# Altium schematic sheet SEPL-306Rev1.03.SchDoc
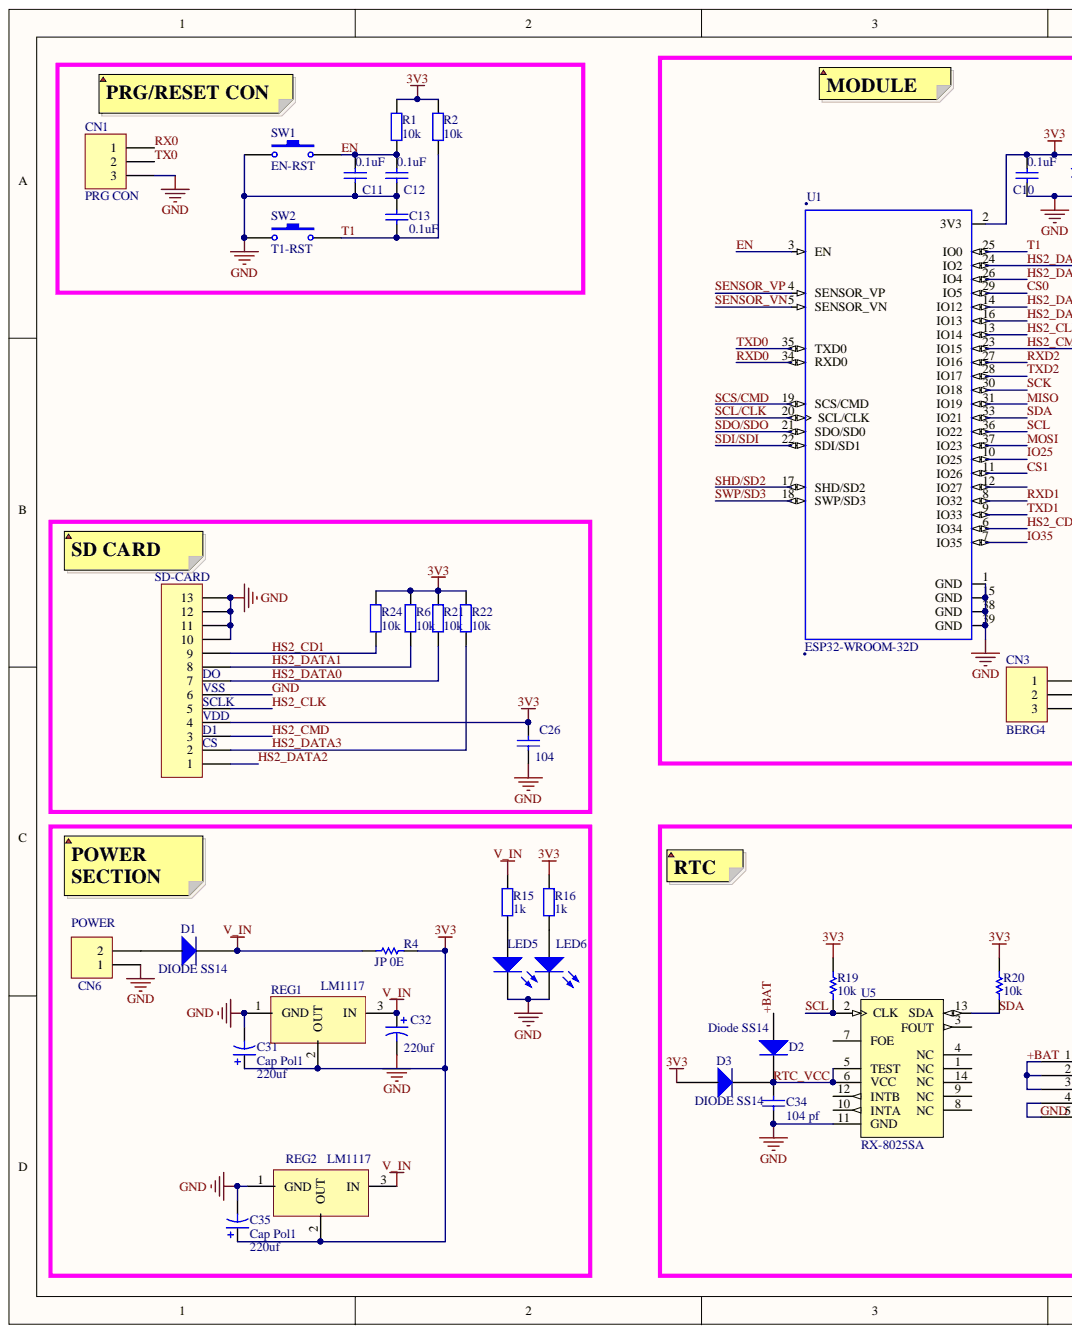
[diagram p0: SEPL-306Rev1.03.SchDoc, left]
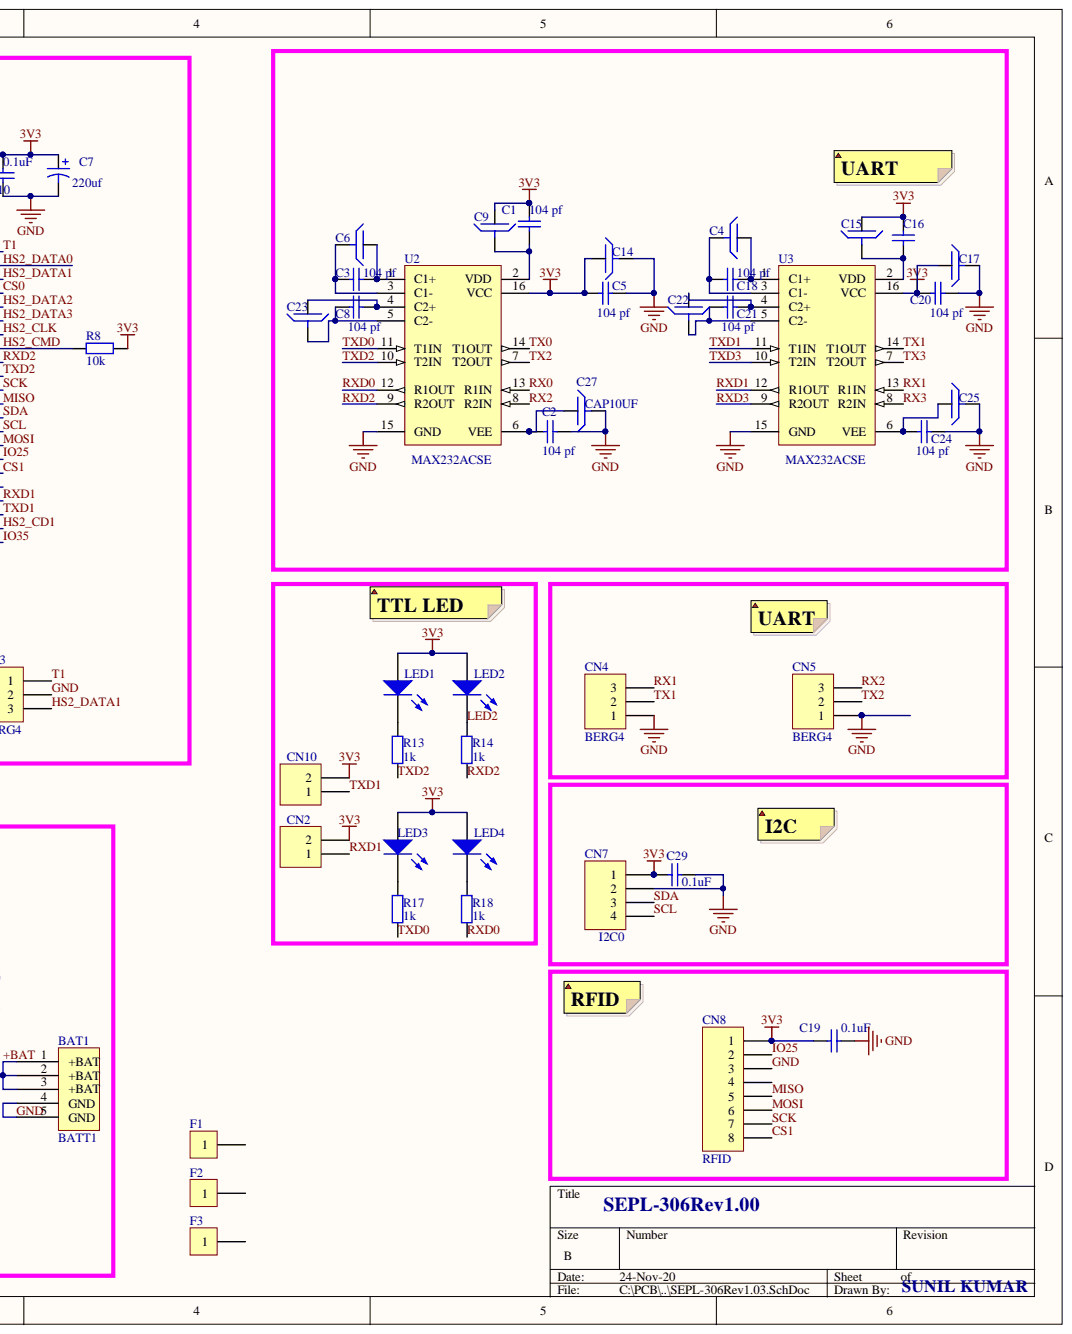
[diagram p1: SEPL-306Rev1.03.SchDoc, right]

## Parts
BAT1  BATT1  [BATT]
C1  104 pf  [RES 0805/2012]
C2  104 pf  [RES 0805/2012]
C3  104 pf  [RES 0805/2012]
C4  CAP10UF  [CAP10UF]  (value not drawn)
C5  104 pf  [RES 0805/2012]
C6  CAP10UF  [CAP10UF]  (value not drawn)
C7  value 220uf  [CAP TH2]  (hidden comment: Cap Pol1)
C8  104 pf  [RES 0805/2012]
C9  CAP10UF  [CAP10UF]  (value not drawn)
C10  value 0.1uF  [RES 0805/2012]
C11  value 0.1uF  [RES 0805/2012]
C12  value 0.1uF  [RES 0805/2012]
C13  value 0.1uF  [RES 0805/2012]
C14  CAP10UF  [CAP10UF]  (value not drawn)
C15  CAP10UF  [CAP10UF]  (value not drawn)
C16  104 pf  [RES 0805/2012]  (value not drawn)
C17  CAP10UF  [CAP10UF]  (value not drawn)
C18  104 pf  [RES 0805/2012]
C19  value 0.1uF  [RES 0805/2012]
C20  104 pf  [RES 0805/2012]
C21  CAP10UF  [CAP10UF]  (value not drawn)
C22  104 pf  [RES 0805/2012]
C23  CAP10UF  [CAP10UF]  (value not drawn)
C24  104 pf  [RES 0805/2012]
C25  CAP10UF  [CAP10UF]  (value not drawn)
C26  104  [RES 0805/2012]
C27  CAP10UF  [CAP10UF]
C29  value 0.1uF  [RES 0805/2012]
C31  Cap Pol1  value 220uf  [CAP TH2]
C32  value 220uf  [CAP TH2]  (hidden comment: Cap Pol1)
C34  104 pf  [RES 0805/2012]
C35  Cap Pol1  value 220uf  [CAP TH2]
CN1  PRG CON  [RELIMATE-3CON]
CN2  (no value)  [RELIMET-2]
CN3  BERG4  [RELIMET-3]
CN4  BERG4  [RELIMATE-3CON]
CN5  BERG4  [RELIMATE-3CON]
CN6  POWER  [RELIMATE-2CON]
CN7  I2C0  [RELIMATE-4CON]
CN8  RFID  [HDR1X8]
CN10  (no value)  [RELIMET-2]
D1  DIODE SS14  [Bourns DO214AC SMA]
D2  Diode SS14  [Bourns DO214AC SMA]
D3  DIODE SS14  [Bourns DO214AC SMA]
F1  FIDUCIAL-1  [FD]  (value not drawn)
F2  FIDUCIAL-1  [FD]  (value not drawn)
F3  FIDUCIAL-1  [FD]  (value not drawn)
LED1  TXD2  [SIP2]  (value not drawn)
LED2  RXD2  [RELIMET-2]  (value not drawn)
LED3  TXD0  [SIP2]  (value not drawn)
LED4  RXD0  [SIP2]  (value not drawn)
LED5  +5V  [RES 0805/2012]  (value not drawn)
LED6  +3V3  [RES 0805/2012]  (value not drawn)
R1  value 10k  [RES 0805/2012]  (hidden comment: Res2)
R2  value 10k  [RES 0805/2012]  (hidden comment: Res2)
R4  JP 0E  [RES 0805/2012]
R6  value 10k  [RES 0805/2012]  (hidden comment: Res2)
R8  value 10k  [RES 0805/2012]  (hidden comment: Res2)
R13  value 1k  [RES 0805/2012]  (hidden comment: Res2)
R14  value 1k  [RES 0805/2012]  (hidden comment: Res2)
R15  value 1k  [RES 0805/2012]  (hidden comment: Res2)
R16  value 1k  [RES 0805/2012]  (hidden comment: Res2)
R17  value 1k  [RES 0805/2012]  (hidden comment: Res2)
R18  value 1k  [RES 0805/2012]  (hidden comment: Res2)
R19  10k  [RES 0805/2012]
R20  10k  [RES 0805/2012]
R21  value 10k  [RES 0805/2012]  (hidden comment: Res2)
R22  value 10k  [RES 0805/2012]  (hidden comment: Res2)
R24  value 10k  [RES 0805/2012]  (hidden comment: Res2)
REG1  value LM1117  [SOT-223A]  (hidden comment: SOT223)
REG2  value LM1117  [SOT-223A]  (hidden comment: SOT223)
SD-CARD  Micro SD_CARD  [SD_CARD HOLDER]  (value not drawn)
SW1  EN-RST  [SW_TACTILE_SMD]
SW2  T1-RST  [SW_TACTILE_SMD]
U1  ESP32-WROOM-32D  [ESP32S_COM]
U2  MAX232ACSE  [MAX232]
U3  MAX232ACSE  [MAX232]
U5  RX-8025SA  [RTC]

## Nets
3V3: C1.1 C5.1 C7.1 C9.1 C10.2 C14.1 C15.1 C16.1 C17.1 C19.2 C20.1 C26.2 C29.2 C31.1 C35.1 CN2.2 CN7.1 CN8.1 CN10.2 D3.1 LED1.1 LED2.1 LED3.1 LED4.1 R1.2 R2.2 R4.2 R6.2 R8.1 R16.2 R19.1 R20.1 R21.2 R22.2 R24.2 REG1.2 REG2.2 SD-CARD.4 U1.2(3V3) U2.16(VCC) U3.16(VCC)
V_IN: C32.1 D1.2 R4.1 R15.2 REG1.3 REG2.3
+BAT: BAT1.1(+BAT) BAT1.2(+BAT) BAT1.3(+BAT) D2.1
CS0: U1.29(IO5)
CS1: CN8.8 U1.11(IO26)
EN: C11.2 C12.2 R1.1 SW1.2 U1.3(EN)
GND: BAT1.4(GND) BAT1.5(GND) C2.1 C5.2 C7.2 C10.1 C11.1 C12.1 C13.1 C14.2 C17.2 C19.1 C20.2 C24.1 C25.2 C26.1 C27.2 C29.1 C31.2 C32.2 C34.2 C35.2 CN1.3 CN3.2 CN4.1 CN5.1 CN6.1 CN7.2 CN8.3 LED5.2 LED6.2 REG1.1 REG2.1 SD-CARD.6 SD-CARD.10 SD-CARD.11 SD-CARD.12 SD-CARD.13 SW1.1 SW2.1 U1.1(GND) U1.15(GND) U1.38(GND) U1.39(GND) U2.15(GND) U3.15(GND) U5.11(GND)
HS2_CD1: R24.1 SD-CARD.9 U1.6(IO34)
HS2_CLK: SD-CARD.5 U1.13(IO14)
HS2_CMD: R8.2 SD-CARD.3 U1.23(IO15)
HS2_DATA0: R21.1 SD-CARD.7 U1.24(IO2)
HS2_DATA1: CN3.3 R6.1 SD-CARD.8 U1.26(IO4)
HS2_DATA2: SD-CARD.1 U1.14(IO12)
HS2_DATA3: R22.1 SD-CARD.2 U1.16(IO13)
IO25: CN8.2 U1.10(IO25)
IO35: U1.7(IO35)
LED2: LED2.2 R14.1
MISO: CN8.5 U1.31(IO19)
MOSI: CN8.6 U1.37(IO23)
RTC_VCC: C34.1 D2.2 D3.2 U5.5(TEST) U5.6(VCC)
RX0: CN1.1 U2.13(R1IN)
RX1: CN4.3 U3.13(R1IN)
RX2: CN5.3 U2.8(R2IN)
RX3: U3.8(R2IN)
RXD0: R18.2 U1.34(RXD0) U2.12(R1OUT)
RXD1: CN2.1 U1.8(IO32) U3.12(R1OUT)
RXD2: R14.2 U1.27(IO16) U2.9(R2OUT)
RXD3: U3.9(R2OUT)
SCK: CN8.7 U1.30(IO18)
SCL: CN7.4 R19.2 U1.36(IO22) U5.2(CLK)
SCL/CLK: U1.20(SCL/CLK)
SCS/CMD: U1.19(SCS/CMD)
SDA: CN7.3 R20.2 U1.33(IO21) U5.13(SDA)
SDI/SDI: U1.22(SDI/SD1)
SDO/SDO: U1.21(SDO/SD0)
SENSOR_VN: U1.5(SENSOR_VN)
SENSOR_VP: U1.4(SENSOR_VP)
SHD/SD2: U1.17(SHD/SD2)
SWP/SD3: U1.18(SWP/SD3)
T1: C13.2 CN3.1 R2.1 SW2.2 U1.25(IO0)
TX0: CN1.2 U2.14(T1OUT)
TX1: CN4.2 U3.14(T1OUT)
TX2: CN5.2 U2.7(T2OUT)
TX3: U3.7(T2OUT)
TXD0: R17.2 U1.35(TXD0) U2.11(T1IN)
TXD1: CN10.1 U1.9(IO33) U3.11(T1IN)
TXD2: R13.2 U1.28(IO17) U2.10(T2IN)
TXD3: U3.10(T2IN)
NetC1_2: C1.2 C9.2 U2.2(VDD)
NetC2_2: C2.2 C27.1 U2.6(VEE)
NetC3_1: C3.1 C6.1 U2.1(C1+)
NetC3_2: C3.2 C6.2 U2.3(C1-)
NetC4_1: C4.1 C18.1 U3.1(C1+)
NetC4_2: C4.2 C18.2 U3.3(C1-)
NetC8_1: C8.1 C23.1 U2.4(C2+)
NetC8_2: C8.2 C23.2 U2.5(C2-)
NetC15_2: C15.2 C16.2 U3.2(VDD)
NetC21_1: C21.1 C22.1 U3.4(C2+)
NetC21_2: C21.2 C22.2 U3.5(C2-)
NetC24_2: C24.2 C25.1 U3.6(VEE)
NetCN6_2: CN6.2 D1.1
NetLED1_2: LED1.2 R13.1
NetLED3_2: LED3.2 R17.1
NetLED4_2: LED4.2 R18.1
NetLED5_1: LED5.1 R15.1
NetLED6_1: LED6.1 R16.1

Not connected: CN8 4; F1 1; F2 1; F3 1; U1 12(IO27); U5 1(NC) 3(FOUT) 4(NC) 7(FOE) 8(NC) 9(NC) 10(INTA) 12(INTB) 14(NC)

## Library data of the parts
C1-C3 C5 C8 C16 C18 C20 C22 C24 C26 C34 = Cap: Capacitor; Value: 100pF; Component Kind: Standard; LatestRevisionDate: 17-Jul-2002; LatestRevisionNote: Re-released for DXP Platform.; PackageReference: RAD-0.3; Published: 8-Jun-2000; Signal Integrity: Cap; Simulation: CAP; Publisher: Altium Limited
  C1-C3 C8 C16 C18 C22 C24: Library Name: Miscellaneous Devices.IntLib
  C5 C20 C26 C34: Library Name: mother board.SCHLIB
C7 C31 C32 C35 = Cap Pol1: Polarized Capacitor (Radial); Component Kind: Standard; LatestRevisionDate: 17-Jul-2002; LatestRevisionNote: Re-released for DXP Platform.; Library Name: Miscellaneous Devices.IntLib; PackageReference: RB7.6-15; Published: 8-Jun-2000; Signal Integrity: Cap Pol; Simulation: CAP; Publisher: Altium Limited
C10-C13 C19 C29 = Cap: Capacitor; Component Kind: Standard; LatestRevisionDate: 17-Jul-2002; LatestRevisionNote: Re-released for DXP Platform.; Library Name: mother board.SCHLIB; PackageReference: RAD-0.3; Published: 8-Jun-2000; Signal Integrity: Cap; Simulation: CAP; Publisher: Altium Limited
CN1 CN3-CN5 = Header 3: Header, 3-Pin; Component Kind: Standard; LatestRevisionDate: 17-Jul-2002; LatestRevisionNote: Re-released for DXP Platform.; Library Name: Miscellaneous Connectors.IntLib; Signal Integrity: Connector; Publisher: Altium Limited
CN2 CN6 CN10 = Header 2: Header, 2-Pin; Component Kind: Standard; LatestRevisionDate: 17-Jul-2002; LatestRevisionNote: Re-released for DXP Platform.; Library Name: Miscellaneous Connectors.IntLib; Signal Integrity: Connector; Publisher: Altium Limited
CN7 = Header 4: Header, 4-Pin; Component Kind: Standard; LatestRevisionDate: 17-Jul-2002; LatestRevisionNote: Re-released for DXP Platform.; Library Name: Miscellaneous Connectors.IntLib; Signal Integrity: Connector; Publisher: Altium Limited
CN8 = Header 8: Header, 8-Pin; Component Kind: Standard; LatestRevisionDate: 17-Jul-2002; LatestRevisionNote: Re-released for DXP Platform.; Library Name: Miscellaneous Connectors.IntLib; Signal Integrity: Connector; Publisher: Altium Limited
D1 D3 = DIODE1: Component Kind: Standard; Library Name: SEPL-130.SCHLIB
D2 = Diode: Default Diode; Code_JEDEC: DO-214-AB; Component Kind: Standard; LatestRevisionDate: 17-Jul-2002; LatestRevisionNote: Re-released for DXP Platform.; PackageReference: SMC; PackageVersion: Sep-1996; Published: 8-Jun-2000; Signal Integrity: Diode; Simulation: DIODE; Library Name: SEPL-130.SCHLIB; Publisher: Altium Limited
LED1-LED6 = LED0: Typical INFRARED GaAs LED; LED TYPE: DIP 2.5MM; Component Kind: Standard; LatestRevisionDate: 08-Jul-2005; LatestRevisionNote: Mech Layer 1 removed.; Library Name: Miscellaneous Devices.IntLib; PackageReference: LED-0; Published: 8-Jun-2000; Simulation: LED0; Publisher: Altium Limited
R1 R2 R6 R8 R13-R18 R21 R22 R24 = Res2: Resistor; Component Kind: Standard; LatestRevisionDate: 17-Jul-2002; LatestRevisionNote: Re-released for DXP Platform.; Library Name: Miscellaneous Devices.IntLib; PackageReference: AXIAL-0.4; Published: 8-Jun-2000; Signal Integrity: Res; Simulation: RESISTOR; Publisher: Altium Limited
R4 = Res1: Resistor; Value: 10K; Component Kind: Standard; LatestRevisionDate: 17-Jul-2002; LatestRevisionNote: Re-released for DXP Platform.; Library Name: Miscellaneous Devices.IntLib; PackageReference: AXIAL-0.3; Published: 8-Jun-2000; Signal Integrity: Res; Simulation: RESISTOR; Publisher: Altium Limited
R19 R20 = Res1: Resistor; Component Kind: Standard; LatestRevisionDate: 17-Jul-2002; LatestRevisionNote: Re-released for DXP Platform.; Library Name: Miscellaneous Devices.IntLib; PackageReference: AXIAL-0.3; Published: 8-Jun-2000; Signal Integrity: Res; Simulation: RESISTOR; Value: 10K; Publisher: Altium Limited
REG1 REG2 = SOT223: REGULETOR; Component Kind: Standard; Library Name: mother board.SCHLIB
SD-CARD = Header 13: Header, 13-Pin; Component Kind: Standard; LatestRevisionDate: 17-Jul-2002; LatestRevisionNote: Re-released for DXP Platform.; Library Name: Miscellaneous Connectors.IntLib; Signal Integrity: Connector; Publisher: Altium Limited
SW1 SW2 = SW-PB: Switch; Component Kind: Standard; LatestRevisionDate: 17-Jul-2002; LatestRevisionNote: Re-released for DXP Platform.; Library Name: Miscellaneous Devices.IntLib; PackageDescription: Switch; 2 Leads; PackageReference: SPST-2; Published: 24-Mar-1999; Publisher: Altium Limited
U1 = ESP32-WROOM-32D: IC: SoC; GPIO, I2C, I2S, IR, SD, SDIO, SPI, UART; 2.7Ã·3.6VDC; 20dBm; MF: Espressif Systems; Availability: Warning; Component Kind: Standard; Library Name: ESP32-WROOM-32D.SchLib; MP: ESP32-WROOM-32D; Package: Module Espressif Systems; Price: 4.86 USD; SnapEDA_Link: https://www.snapeda.com/parts/ESP32-WROOM-32D/Espressif%20Systems/view-part/?ref=snap
U2 U3 = MAX232ACSE: +5V Powered, Multi-Channel RS-232 Driver/Receiver; Code_IPC: SOIC127P600-16; Code_JEDEC: MS-012-AC; Component Kind: Standard; ComponentLink1Description: Manufacturer Link; ComponentLink1URL: http://www.maxim-ic.com/; ComponentLink2Description: Datasheet; ComponentLink2URL: http://pdfserv.maxim-ic.com/en/ds/MAX220-MAX249.pdf; LatestRevisionDate: 13-Apr-2006; LatestRevisionNote: IPC-7351 Footprint Added.; Library Name: Maxim Communication Transceiver.IntLib; PackageDescription: 16-Pin Small Outline Integrated Circuit 1.27 mm Pitch; PackageReference: NSO16; PCB3D: NSO16; Published: 16-Jan-2001; Publisher: Altium Limited
U5 = HCF4006BM1: 18-Stage Static Shift Register; Code_IPC: SOIC127P600-14; Component Kind: Standard; ComponentLink1Description: Manufacturer Link; ComponentLink1URL: http://www.st.com/; ComponentLink2Description: Datasheet; ComponentLink2URL: http://www.st.com/stonline/products/literature/ds/2008/hcf4006.pdf; DatasheetVersion: Jun-1989; LatestRevisionDate: 13-Apr-2006; LatestRevisionNote: IPC-7351 Footprint Added.; Library Name: ST Logic Register.IntLib; PackageDescription: 14-Pin Small Outline Integrated Circuit 1.27 mm Pitch; PackageReference: SO14; PackageVersion: Sep-1998; PCB3D: SO14; Published: 8-Jun-2000; Simulation: HCF4006; Publisher: Altium Limited
BAT1 = BATT1: Component Kind: Standard; Library Name: mother board.SCHLIB
C4 C6 C9 C14 C15 C17 C21 C23 C25 C27 = CAP10UF: Component Kind: Standard; Library Name: Integrated_Library1.IntLib
F1-F3 = FIDUCIAL-1: Component Kind: Standard; Library Name: mother board.SCHLIB
